# Altium schematic sheet lv_comm.SchDoc(lv_comm)
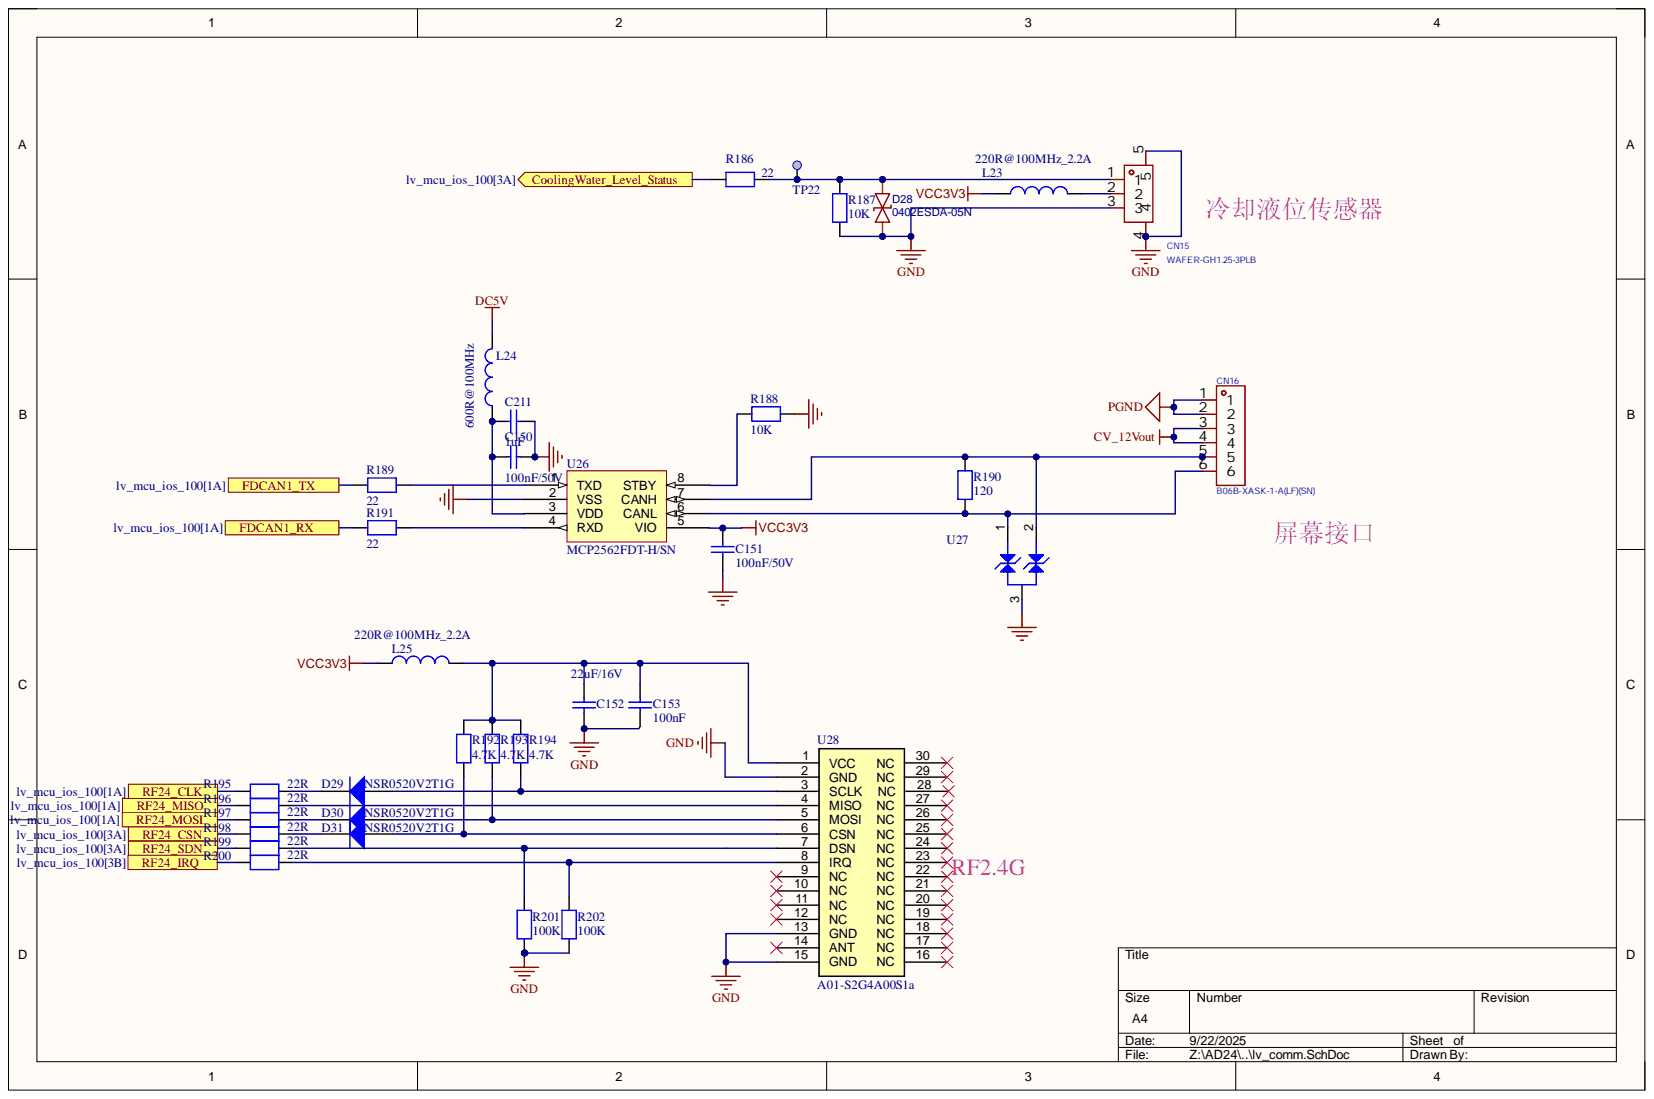
[diagram p0: lv_comm.SchDoc]

## Parts
C150  100nF/50V  [C0402]
C151  100nF/50V  [C0402]
C152  22uF/16V  [C1206]
C153  100nF  [C0402]
C211  value 1uF  [C0603]
CN15  WAFER-GH1.25-3PLB  [CONN-SMD_3P-P1.25_XUNPU_WAFER-GH1.25-3PLB]
CN16  B06B-XASK-1-A(LF)(SN)  [CONN-TH_B06B-XASK-1-A-LF-SN]
D28  0402ESDA-05N  [D0402-BI]
D29  NSR0520V2T1G  [SOD-523F_L1.2-W0.8-LS1.7-RD]
D30  NSR0520V2T1G  [SOD-523F_L1.2-W0.8-LS1.7-RD]
D31  NSR0520V2T1G  [SOD-523F_L1.2-W0.8-LS1.7-RD]
L23  220R@100MHz_2.2A  [L0603]
L24  600R@100MHz  [L0603]
L25  220R@100MHz_2.2A  [L0603]
R186  22  [R0402]
R187  10K  [R0402]
R188  10K  [R0402]
R189  22  [R0402]
R190  120  [R0603]
R191  22  [R0402]
R192  4.7K  [R0402]
R193  4.7K  [R0402]
R194  4.7K  [R0402]
R195  22R  [R0402]
R196  22R  [R0402]
R197  22R  [R0402]
R198  22R  [R0402]
R199  22R  [R0402]
R200  22R  [R0402]
R201  100K  [R0402]
R202  100K  [R0402]
TP22  Test Point  [TP_SMD_1MM]  (value not drawn)  (no BOM)
U26  MCP2562FD  value MCP2562FDT-H/SN  Manufacturer_Part_Number: MCP2562FDT-H/SN  [ICC_SO8_5X4_P127]
U27  ESDCAN03-2BWY  [DIO_SOT323]  (value not drawn)
U28  A01-S2G4A00S1a  [S24-2020.4.1]

## Ports
CoolingWater_Level_Status, FDCAN1_RX, FDCAN1_TX, RF24_CLK, RF24_CSN, RF24_IRQ, RF24_MISO, RF24_MOSI, RF24_SDN

## Nets
CV_12Vout: CN16.3 CN16.4
DC5V: L24.2
GND: C150.2 C151.2 C152.1 C153.2 C211.2 CN15.3 CN15.4 CN15.5 D28.1 R187.1 R188.2 R201.1 R202.1 U26.2(VSS) U27.3 U28.2(GND) U28.13(GND) U28.15(GND)
PGND: CN16.1 CN16.2
VCC3V3: C151.1 L23.2 L25.2 U26.5(VIO)
CoolingWater_Level_Status: R186.1 ; port CoolingWater_Level_Status
FDCAN1_RX: R191.1 ; port FDCAN1_RX
FDCAN1_TX: R189.1 ; port FDCAN1_TX
RF24_CLK: R195.1 ; port RF24_CLK
RF24_CSN: R198.1 ; port RF24_CSN
RF24_IRQ: R200.1 ; port RF24_IRQ
RF24_MISO: R196.1 ; port RF24_MISO
RF24_MOSI: R197.1 ; port RF24_MOSI
RF24_SDN: R199.1 ; port RF24_SDN
NetC150_1: C150.1 C211.1 L24.1 U26.3(VDD)
NetC152_2: C152.2 C153.1 L25.1 R192.1 R193.1 R194.1 U28.1(VCC)
NetCN15_1: CN15.1 D28.2 R186.2 R187.2 TP22.1
NetCN15_2: CN15.2 L23.1
NetCN16_5: CN16.5 R190.1 U26.7(CANH) U27.2
NetCN16_6: CN16.6 R190.2 U26.6(CANL) U27.1
NetD29_1: D29.1 R195.2
NetD29_2: D29.2 R194.2 U28.3(SCLK)
NetD30_1: D30.1 R197.2
NetD30_2: D30.2 R193.2 U28.5(MOSI)
NetD31_1: D31.1 R198.2
NetD31_2: D31.2 R192.2 U28.6(CSN)
NetR188_1: R188.1 U26.8(STBY)
NetR189_2: R189.2 U26.1(TXD)
NetR191_2: R191.2 U26.4(RXD)
NetR196_2: R196.2 U28.4(MISO)
NetR199_2: R199.2 R201.2 U28.7(DSN)
NetR200_2: R200.2 R202.2 U28.8(IRQ)

Not connected: U28 9(NC) 10(NC) 11(NC) 12(NC) 14(ANT) 16(NC) 17(NC) 18(NC) 19(NC) 20(NC) 21(NC) 22(NC) 23(NC) 24(NC) 25(NC) 26(NC) 27(NC) 28(NC) 29(NC) 30(NC)

## Library data of the parts
C150 C151 = MCD-00006: 100nF, 50V, X7R, 10%; Manufacturer: YAGEO; Manufacturer_Part_Number: GRM155R71H104KE14D; Database Table Name: MCD_PARTS$; Component Kind: Standard; ComponentLink1Description: Datasheet; ComponentLink1URL: http://tunmcdsvn.tun.st.com/Component_Datasheet_Library/Trunk/Capacitors/Yageo_Multilayer_Capacitor_X7R_series_100pF_to_22uF; Library Name: MCD_PARTS_database.DbLib
C152 = Capacitor_1: 16V, X5R, 10%; Manufacturer: muRata; Manufacturer_Part_Number: GRM31CR61C226KE15L; Component Kind: Standard; Library Name: HiPin_Library_AD.IntLib
C153 = Capacitor: 50V, 10%, X7R; Internal_Part_Number: MCD-00003; Manufacturer: muRata; Manufacturer_Part_Number: GRM155R71H104KE14D; Component Kind: Standard; ComponentLink1Description: Datasheet; ComponentLink1URL: http://tunmcdsvn.tun.st.com/Component_Datasheet_Library/Trunk/Capacitors/Yageo_Capacitor_NP0_16V-to-50V; Library Name: HiPin_Library_AD.IntLib; Package: C0402; Value: 100nF
C211 = MCD-00010: Capacitor, MLCC, 1uF, 16V, X5R, 10%, 0603, T=0,8mm; Manufacturer: YAGEO; Manufacturer_Part_Number: CC0603KRX5R7BB105; Database Table Name: MCD_PARTS$; Initial_Board_Reference: MB1274; Provided_By: Subcon; Component Kind: Standard; ComponentLink1Description: Yageo_Capacitor_X5R-series.pdf; ComponentLink1URL: http://tunmcdsvn.tun.st.com/Component_Datasheet_Library/Trunk/Capacitors/Yageo_Multilayer_capacitor_X5R_series_100pF_to_100uF; ECCN_EU: n/a; ECCN_US: n/a; Internal_Part_Number: MCD-00010; Library Name: MCD_PARTS_database.DbLib; Package: C0603; Part_Type: Capacitor; Status: Released
CN15 = WAFER-GH1.25-3PLB: 插座系列: GH,  立贴结构: 1x3P, 间距: 1.25mm; Manufacturer: XUNPU(讯普); Add into BOM: yes; Current Rating: 1A; Number of PINs Per Row: 3; Plastic Material: LCP; Supplementary Features: 辅助焊脚; Voltage Rating: 125V; X-Length of Bottom Edge on Board (Spacing Line): 7mm; Y-Width of Bottom Edge on Board: 4.95mm; Z-Height of the Board: 4.2mm; Color: 米色; Component Kind: Standard; Contact Material: 黄铜; Contact Plating: -; Convert to PCB: yes; Datasheet: https://atta.szlcsc.com/upload/public/pdf/source/20220527/44F824AAC8C2B617205E15955FF9C23D.pdf; Device: WAFER-GH1.25-3PLB; Flame Retardant Rating: -; JLCPCB Part Class: Extended Part; LCSC Part Name: 1x3P 间距:1.25mm 立贴 系列:GH; Library Name: HiPin_Library_AD.IntLib; Manufacturer Part: WAFER-GH1.25-3PLB; Mounting Type: 立贴; Number of Pins: 3P; Number of Rows: 1; Operating Temperature: -25℃~+85℃; PCB3D: CONN-SMD_3P-P1.25_XUNPU_WAFER-GH1.25-3PLB; Pins Structure: 1x3P; Pitch: 1.25mm; Reference Series: GH; Row Spacing: -; Supplier: LCSC; Supplier Footprint: SMD,P=1.25mm; Supplier Part: C3029369; Symbol: WAFER-GH1.25-3PLB
CN16 = B06B-XASK-1-A(LF)(SN): 插座XA2.5mm,3A,H8.8mm; Manufacturer: JST; Add into BOM: yes; Flame Retardant Rating: UL94V-0; Number of PINs Per Row: 6; Pins Structure: 1x6P; Pitch: 2.5mm; Plastic Material: 玻纤增强PA66; Rated Voltage (Max): 250V; Reference Series: XA; Supplementary Features: 打K脚；带柱; X-Length of Bottom Edge on Board (Spacing Line): 17.5mm; Y-Width of Bottom Edge on Board: 6.4mm; Z-Height of the Board: 8.8mm; Colour: 白色; Component Kind: Standard; Contact Material: 铜合金; Contact Plating: 锡; Convert to PCB: yes; Current Rating (Max): 3A; Datasheet: https://atta.szlcsc.com/upload/public/pdf/source/20220509/C25BFBA8A7816C529B22589B590C7AEA.pdf; Device: B06B-XASK-1-A(LF)(SN); JLCPCB Part Class: Extended Part; LCSC Part Name: 1x6P 间距:2.5mm 直插 系列:XA; Library Name: HiPin_Library_AD.IntLib; Manufacturer Part: B06B-XASK-1-A(LF)(SN); Mounting Style: 直插; Number of Pins: 6P; Number of Rows: 1; Operating Temperature Range: -25℃~+85℃; PCB3D: CONN-TH_B06B-XASK-1-A-LF-SN; Row Spacing: -; Supplier: LCSC; Supplier Footprint: 插件,P=2.5mm; Supplier Part: C491711; Symbol: B06B-XASK-1-A(LF)(SN)
D28 = 0402ESDA-05N: BOM_Manufacturer: Bourne Semicon (Shenzhen); Contributor: LCSC; BOM_JLCPCB Part Class: Extended Part; BOM_Manufacturer Part: 0402ESDA-05N; BOM_Supplier: LCSC; BOM_Supplier Part: C316049; Component Kind: Standard; Library Name: HiPin_Library_AD.IntLib; spicePre: D; spiceSymbolName: 0402ESDA-05N
D29-D31 = 1N4148: Component Kind: Standard; Library Name: HiPin_Library_AD.IntLib
L23 L25 = MCD-00047: SMD Chip ferrite bead, 220R@100MHz +/-25%,2.2A, 50mohm; Manufacturer: Sunlord; Manufacturer_Part_Number: UPZ1608U221-2R2TF; Database Table Name: MCD_PARTS$; Component Kind: Standard; ComponentLink1Description: Datasheet; ComponentLink1URL: http://tunmcdsvn.tun.st.com/Component_Datasheet_Library/Trunk/Inductors/TaiTech_Bead_FCM1608_series; Internal_Part_Number: MCD-00047; Library Name: MCD_PARTS_database.DbLib
L24 = MCD-00047: +/-25%, 350mA, 500mohm DC; Manufacturer_Part_Number: FCM1608KF-601T03; Database Table Name: MCD_PARTS$; Component Kind: Standard; ComponentLink1Description: Datasheet; ComponentLink1URL: http://tunmcdsvn.tun.st.com/Component_Datasheet_Library/Trunk/Inductors/TaiTech_Bead_FCM1608_series; Library Name: MCD_PARTS_database.DbLib
R186 R189-R191 R195-R202 = RES: 1%; Value: 10K; Component Kind: Standard; Library Name: HiPin_Library_AD.IntLib
R187 R188 R192-R194 = RES: 1%; Component Kind: Standard; Library Name: HiPin_Library_AD.IntLib
  R187 R188: Value: 10K
TP22 = MCD-00074: Test Point 1mm; Part_Type: Mechanical; Database Table Name: MCD_PARTS$; ComponentLink1Description: Datasheet; ComponentLink1URL: http://na; ECCN_EU: n/a; ECCN_US: n/a; Internal_Part_Number: MCD-00074; Library Name: MCD_PARTS_database.DbLib; Manufacturer: n/a; Manufacturer_Part_Number: n/a; Provided_By: n/a; Status: Released
U26 = MCD-00129: High-Speed CAN Flexible Data Rate Transceiver; Manufacturer: MICROCHIP; Part_Type: IC; Database Table Name: MCD_PARTS$; Initial_Board_Reference: MB1262; Item Type: Item; Path: ws/MCD_ApplicationBoards/Lists/MCDPARTSdatabase; Provided_By: SUBCO; Component Kind: Standard; ComponentLink1Description: Datasheet; ComponentLink1URL: http://tunmcdsvn.tun.st.com/Component_Datasheet_Library/Trunk/IC/Microchip_CAN Transceiver_MCP2562FD; Internal_Part_Number: MCD-00129; Library Name: MCD_PARTS_database.DbLib; Status: Released
U27 = MCD-01228: 极性  双向  反向截止电压(Vrwm)  24V  钳位电压  41V  峰值脉冲电流(Ipp)  3.7A@8/20us; Manufacturer: STMICROELECTRONICS; Database Table Name: MCD_PARTS$; Component Kind: Standard; Library Name: MCD_PARTS_database.DbLib; Manufacturer_Part_Number: ESDCAN03-2BWY
U28 = A01-S2G4A00S1a: RF2.4G; Component Kind: Standard; Library Name: HiPin_Library_AD.IntLib
